# Altium schematic sheet 2-VoltProtector_CurrSens.SchDoc(2-VoltProtector_CurrSens)
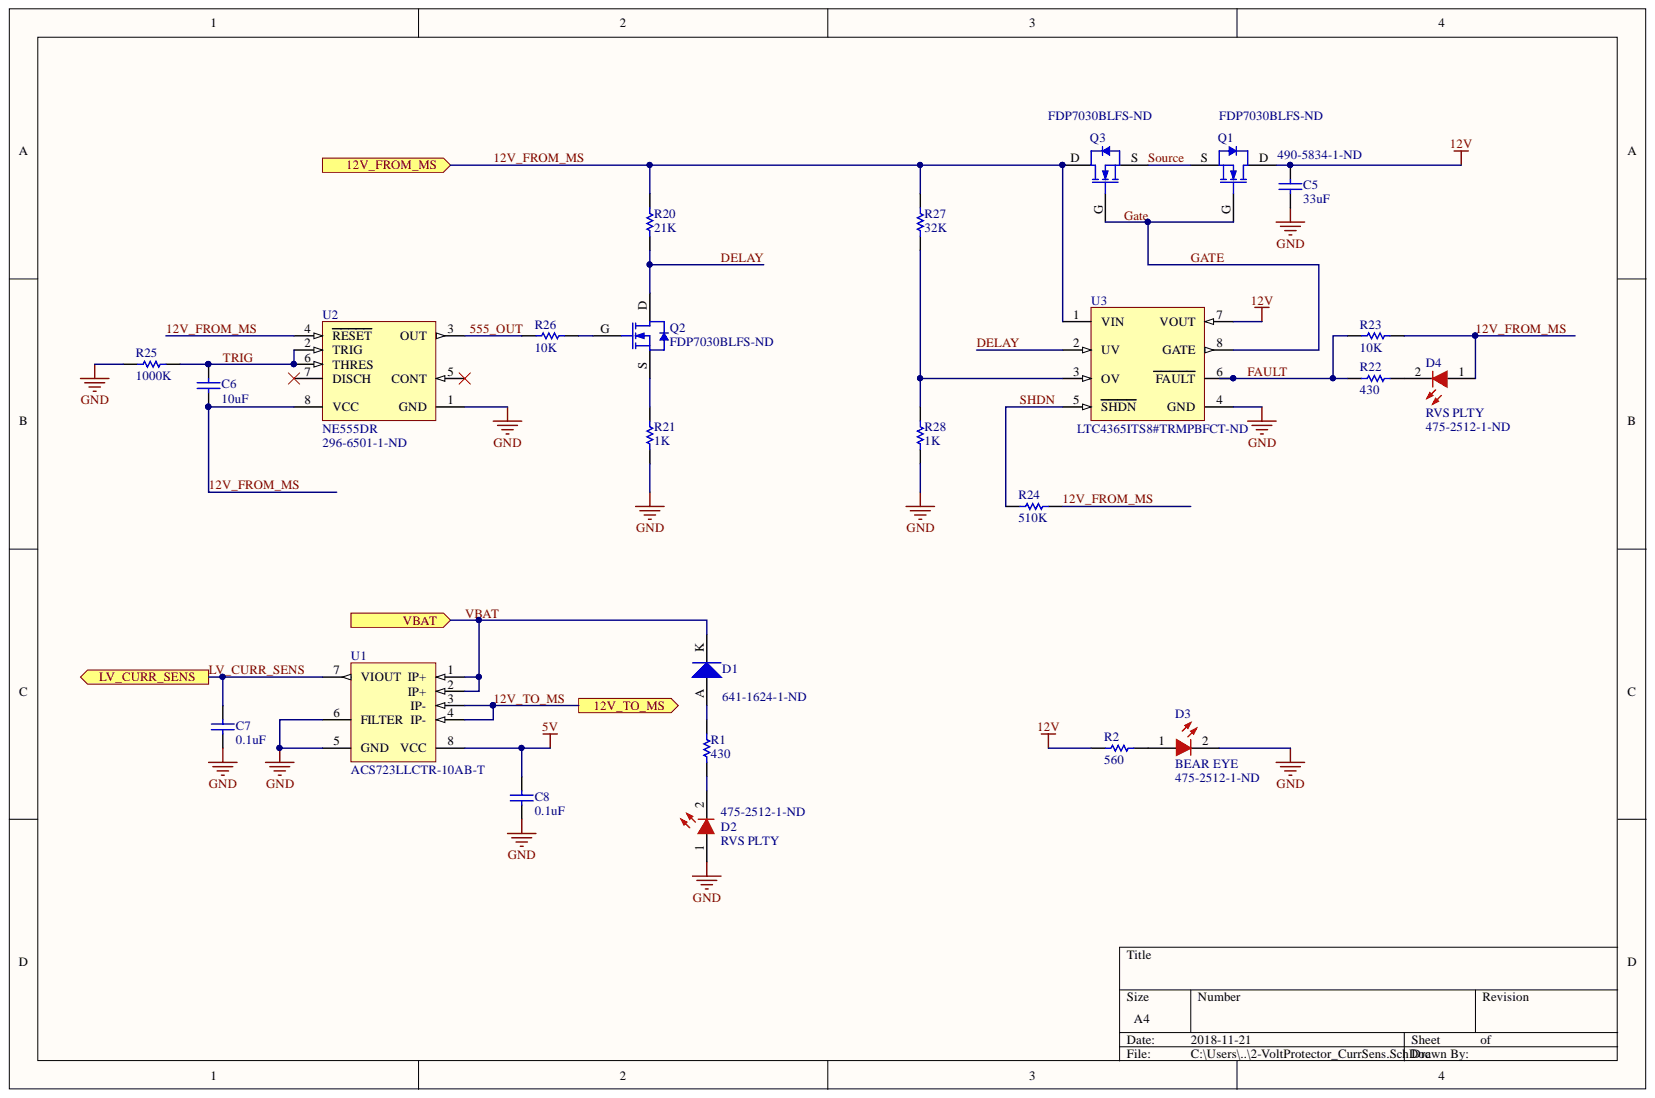
[diagram p0: 2-VoltProtector_CurrSens.SchDoc]

## Parts
C5  value 33uF  Supplier Part Number 1: 490-5834-1-ND  [2J-Lead 6.1 x 5.3]  (hidden comment: Cap Semi)
C6  value 10uF  [2J-Lead 6.1 x 5.3]  (hidden comment: Cap Semi)
C7  value 0.1uF  [1608[0603]]  (hidden comment: Cap Semi)
C8  value 0.1uF  [1608[0603]]  (hidden comment: Cap Semi)
D1  Supplier Part Number 1: 641-1624-1-ND  [SOD-123]
D2  RVS PLTY  Supplier Part Number 1: 475-2512-1-ND  [TOSH-0603LED_RED_V]
D3  BEAR EYE  Supplier Part Number 1: 475-2512-1-ND  [TOSH-0603LED_RED_V]
D4  RVS PLTY  Supplier Part Number 1: 475-2512-1-ND  [TOSH-0603LED_RED_V]
Q1  Supplier Part Number 1: FDP7030BLFS-ND  [TO220V-GDS]
Q2  Supplier Part Number 1: FDP7030BLFS-ND  [TO220V-GDS]
Q3  Supplier Part Number 1: FDP7030BLFS-ND  [TO220V-GDS]
R1  430  [RESC0603(1608)_L]
R2  560  [RESC0603(1608)_L]
R20  21K  [RESC0603(1608)_L]
R21  1K  [RESC0603(1608)_L]
R22  430  [RESC0603(1608)_L]
R23  10K  [RESC0603(1608)_L]
R24  510K  [RESC0603(1608)_L]
R25  1000K  [RESC0603(1608)_L]
R26  10K  [RESC0603(1608)_L]
R27  32K  [RESC0603(1608)_L]
R28  1K  [RESC0603(1608)_L]
U1  ACS723LLCTR-10AB-T  [ALEG-LC-8_V]
U2  NE555DR  Supplier Part Number 1: 296-6501-1-ND  [D0008A_M]
U3  LTC4365ITS8#TRMPBF  Supplier Part Number 1: LTC4365ITS8#TRMPBFCT-ND  [LT-TS8-8-TSOT-23_V]

## Ports
12V_FROM_MS, 12V_TO_MS, LV_CURR_SENS, VBAT

## Nets
5V: C8.2 U1.8(VCC)
12V: C5.1 Q1.D R2.1 U3.7(VOUT)
GND: C5.2 C7.1 C8.1 D2.1 D3.2 R21.2 R25.2 R28.1 U1.5(GND) U1.6(FILTER) U2.1(GND) U3.4(GND)
12V_FROM_MS: C6.2 D4.1 Q3.D R20.1 R23.1 R24.1 R27.2 U2.4(RESET) U2.8(VCC) U3.1(VIN) ; port 12V_FROM_MS
12V_TO_MS: U1.3(IP-) U1.4(IP-) ; port 12V_TO_MS
555_OUT: R26.2 U2.3(OUT)
DELAY: Q2.D R20.2 U3.2(UV)
FAULT: R22.1 R23.2 U3.6(FAULT)
Gate: Q1.G Q3.G U3.8(GATE)
LV_CURR_SENS: C7.2 U1.7(VIOUT) ; port LV_CURR_SENS
SHDN: R24.2 U3.5(SHDN)
Source: Q1.S Q3.S
TRIG: C6.1 R25.1 U2.2(TRIG) U2.6(THRES)
VBAT: D1.K U1.1(IP+) U1.2(IP+) ; port VBAT
NetD1_A: D1.A R1.2
NetD2_2: D2.2 R1.1
NetD3_1: D3.1 R2.2
NetD4_2: D4.2 R22.2
NetQ2_G: Q2.G R26.1
NetQ2_S: Q2.S R21.1
NetR27_1: R27.1 R28.2 U3.3(OV)

Not connected: U2 5(CONT) 7(DISCH)

## Library data of the parts
C5-C8 = Cap Semi: Capacitor (Semiconductor SIM Model); Simulation: CAP; Published: 8-Jun-2000; PackageReference: C1206; PackageDescription: Chip Capacitor; Library Name: Miscellaneous Devices.IntLib; LatestRevisionNote: IPC-7351 Footprint Added.; LatestRevisionDate: 29-May-2009; Component Kind: Standard; Publisher: Altium Limited
  C5: Supplier 1: Digi-Key
  C7 C8: Supplier Part Number 2: 490-14359-1-ND; Supplier 2: Digi-Key; Supplier 1: Digi-Key
D1 = Diode 1N4003: 1 Amp General Purpose Rectifier; Supplier 1: Digi-Key; Simulation: 1N4003; Published: 8-Jun-2000; PackageVersion: Aug-1999; PackageReference: DO-41; PackageDescription: Diode, Axial; Body 5.2 x 2.7 mm (LxDia. max), Lead Dia. 0.86 mm (max); Library Name: Miscellaneous Devices.IntLib; LatestRevisionNote: Re-released for DXP Platform.; LatestRevisionDate: 17-Jul-2002; DatasheetVersion: 1998; ComponentLink2URL: http://www.fairchildsemi.com/ds/1N%2F1N4001.pdf; ComponentLink2Description: Datasheet; ComponentLink1URL: http://www.fairchildsemi.com/; ComponentLink1Description: Manufacturer Link; Component Kind: Standard; Code_JEDEC: DO-204-AL; Publisher: Altium Limited
D2-D4 = CMP-0444-00001-1: LED, Red 0603 (1608) 63mCd; PartNumber: TLSU1008A(T04); Manufacturer: Toshiba; Reverse Voltage: 4 V; Power Dissipation: 60 mW; Packing Type: Reel; Forward Current: 25 mA; Supplier 1: Digi-Key; Storage Temperature: -40 to 100 degC; PackageReference: 0603LED_RED; PackageDescription: 2-Pin SMT LED, 1.6 x 0.8 mm Pitch; Mounting Technology: Surface Mount; Library Name: Altium Content Vault; DatasheetVersion: Aug-08; ComponentLink2URL: http://www.semicon.toshiba.co.jp/info/docget.jsp?type=datasheet&lang=en&pid=TLSU1008A(T04); ComponentLink2Description: Datasheet; ComponentLink1URL: http://www.toshiba-components.com/; ComponentLink1Description: Manufacturer URL; Component Kind: Standard
Q1-Q3 = CMP-1058-00860-1: Power MOSFET, 40 V, 167 A, Single N-Channel, 3-Pin TO-220, Pb-Free, Tube; Qrr Typ (nC): 140; ID Max (A): 167; Crss Typ (pF): 450; Coss Typ (pF): 1075; Ciss Typ (pF): 4300; Channel Polarity: N Channel; Supplier 1: Digi-Key; RoHS: Pb-Free; Packing: Tube; PackageVersion: Rev. AG, 09/2011; Package Type: TO-220-3; PackageReference: TO-220-3-221A-09; PackageDescription: 3-Pin Flange Mount, Vertical Straight Lead, Body 9.97 x 4.445 mm, Pitch 2.54 mm; Mounting Technology: Through Hole; DatasheetVersion: Rev. 7; Configuration: Single; Component Kind: Standard
R1 R2 R20-R28 = CMP-1591-00001-1: 0603 (1608 Metric) Chip Resistor; Case-Metric: 1608; Case-EIA: 0603; PackageReference: RESC0603(1608); Library Name: Altium Content Vault; Component Kind: Standard
  R1 R22: Supplier Part Number 1: P430BZCT-ND; Supplier 1: Digi-Key
  R2: Supplier Part Number 1: P560BZCT-ND; Supplier 1: Digi-Key
  R23 R26: Supplier Part Number 1: 311-10KGRCT-ND; Supplier 1: Digi-Key
  R25 R27 R28: Supplier 1: Digi-Key
U1 = CMP-0826-00001-1: Linear Current Sensor IC, 4.5 to 5.5 V Supply Voltage, 10 mA Supply Current, -40 to 85 degC, 8-Pin SOIC (LC), RoHS, Tape and Reel; PartNumber: ACS712ELCTR-20A-T; Manufacturer: Allegro Microsystems; TR: 3.5 us; Sensitivity Sens: 100 mV/A Typ.; RLOAD: 4.7 kOhm Min.; Optimized Accuracy Range: +/- 20 A; ICC: 10 mA; F: 80 kHz; CLOAD: 10 nF Max.; VCC: 4.5 to 5.5 V; Supplier Part Number 1: 620-1190-1-ND; Supplier 1: Digi-Key; RoHS: TRUE; Packing: Tape and Reel; PackageReference: LC-8; PackageDescription: 8-Pin SOIC, Body 4.9 x 3.9 mm, Pitch 1.27 mm; Operating Temperature Range: -40 to 85 degC; Mounting Technology: Surface Mount; Library Name: Altium Content Vault; DatasheetVersion: Rev. 15; ComponentLink2URL: http://www.allegromicro.com/~/media/Files/Datasheets/ACS712-Datasheet.ashx; ComponentLink2Description: Datasheet; ComponentLink1URL: http://www.allegromicro.com/; ComponentLink1Description: Manufacturer URL; Component Kind: Standard
U2 = CMP-1685-00007-1: Precision Timer, 4.5 to 16 V, 0 to 70 degC, 8-Pin SOIC (D8), Green (RoHS & no Sb/Br), Tape and Reel; Peak Reverse Current: 500 uA; Max Surge Current: 30 A; Forward Voltage: 450 mV; Forward Current: 1 A; Case\Package: SMA; Width: 2.84 mm; Supplier 1: Digi-Key; RoHSCompliant: true; REACH SVHC: Unknown; Radiation Hardening: No; Pins: 2; Packaging: Cut Tape; PackageVersion: 4040047-3/M, 06/2011; PackageReference: D0008A; Package Quantity: 1; PackageDescription: 8-Pin Small Outline Integrated Circuit, Body 4.9 x 3.9 mm, Pitch 1.27 mm; Mount: Surface Mount; Min Operating Temperature: -55 degC; Max Operating Temperature: 125 degC; Library Name: Altium Content Vault; Length: 4.57 mm; Height: 2.44 mm; DatasheetVersion: SLFS022I, 09/2014; ComponentLink3URL: http://www.ti.com/lit/ml/msoi002j/msoi002j.pdf; ComponentLink3Description: Package Specification; ComponentLink2URL: http://www.ti.com/general/docs/lit/getliterature.tsp?genericPartNumber=NE555&fileType=pdf; ComponentLink2Description: Datasheet; ComponentLink1URL: http://www.ti.com/; ComponentLink1Description: Manufacturer URL; Component Kind: Standard; Code_JEDEC: MS-012
U3 = CMP-0474-00861-1: UV, OV and Reverse Supply Protection Controller, 2.5 to 34 V Vin, 8-pin SOT23 (TS8-8), -40 to 85 degC, Pb-Free, Mini Tape and Reel; Manufacturer: Linear Technology; Reset: no; Fault: yes; Extended Temp: C, I, H; Circuit Breakers(#): 1; Vin Range: 2.5 to 34 V; Supplier 1: Digi-Key; RoHS: TRUE; Power Good: no; PartNumber: LTC4365ITS8#TRMPBF; Packing: Mini Tape and Reel; PackageVersion: Rev. A, 08/2010; PackageReference: TS8-8; PackageDescription: 8-Pin Plastic TSOT-23, Body 2.9 x 1.63 mm, Pitch 0.65 mm; Mounting Technology: Surface Mount; Library Name: Altium Content Vault; Foldback: no; Fast Comparator: no; ComponentLink3URL: http://cds.linear.com/docs/en/packaging/SOT_8_05-08-1637.pdf; ComponentLink3Description: Package Specification; ComponentLink2URL: http://cds.linear.com/docs/en/datasheet/4365f.pdf; ComponentLink2Description: Datasheet; ComponentLink1URL: http://www.linear.com/; ComponentLink1Description: Manufacturer URL; Component Kind: Standard; Code_JEDEC: MO-193; Active Limiting: no
